# Altium schematic sheet MCU.SchDoc
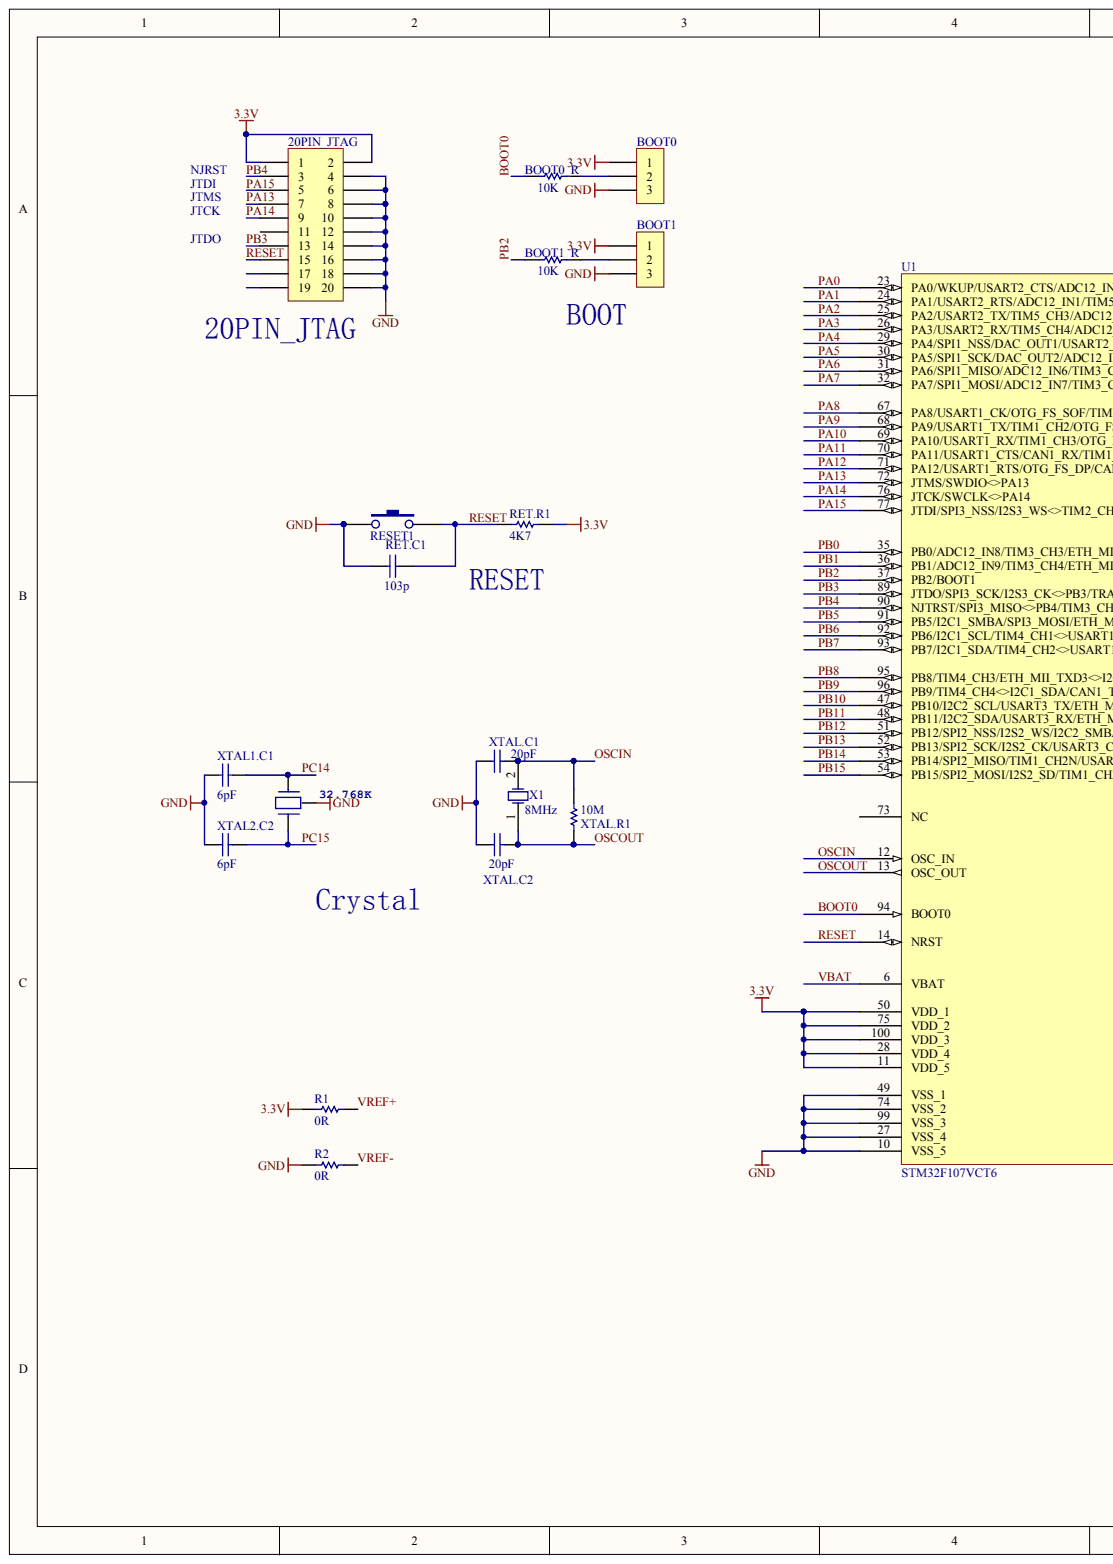
[diagram p0: MCU.SchDoc, left]
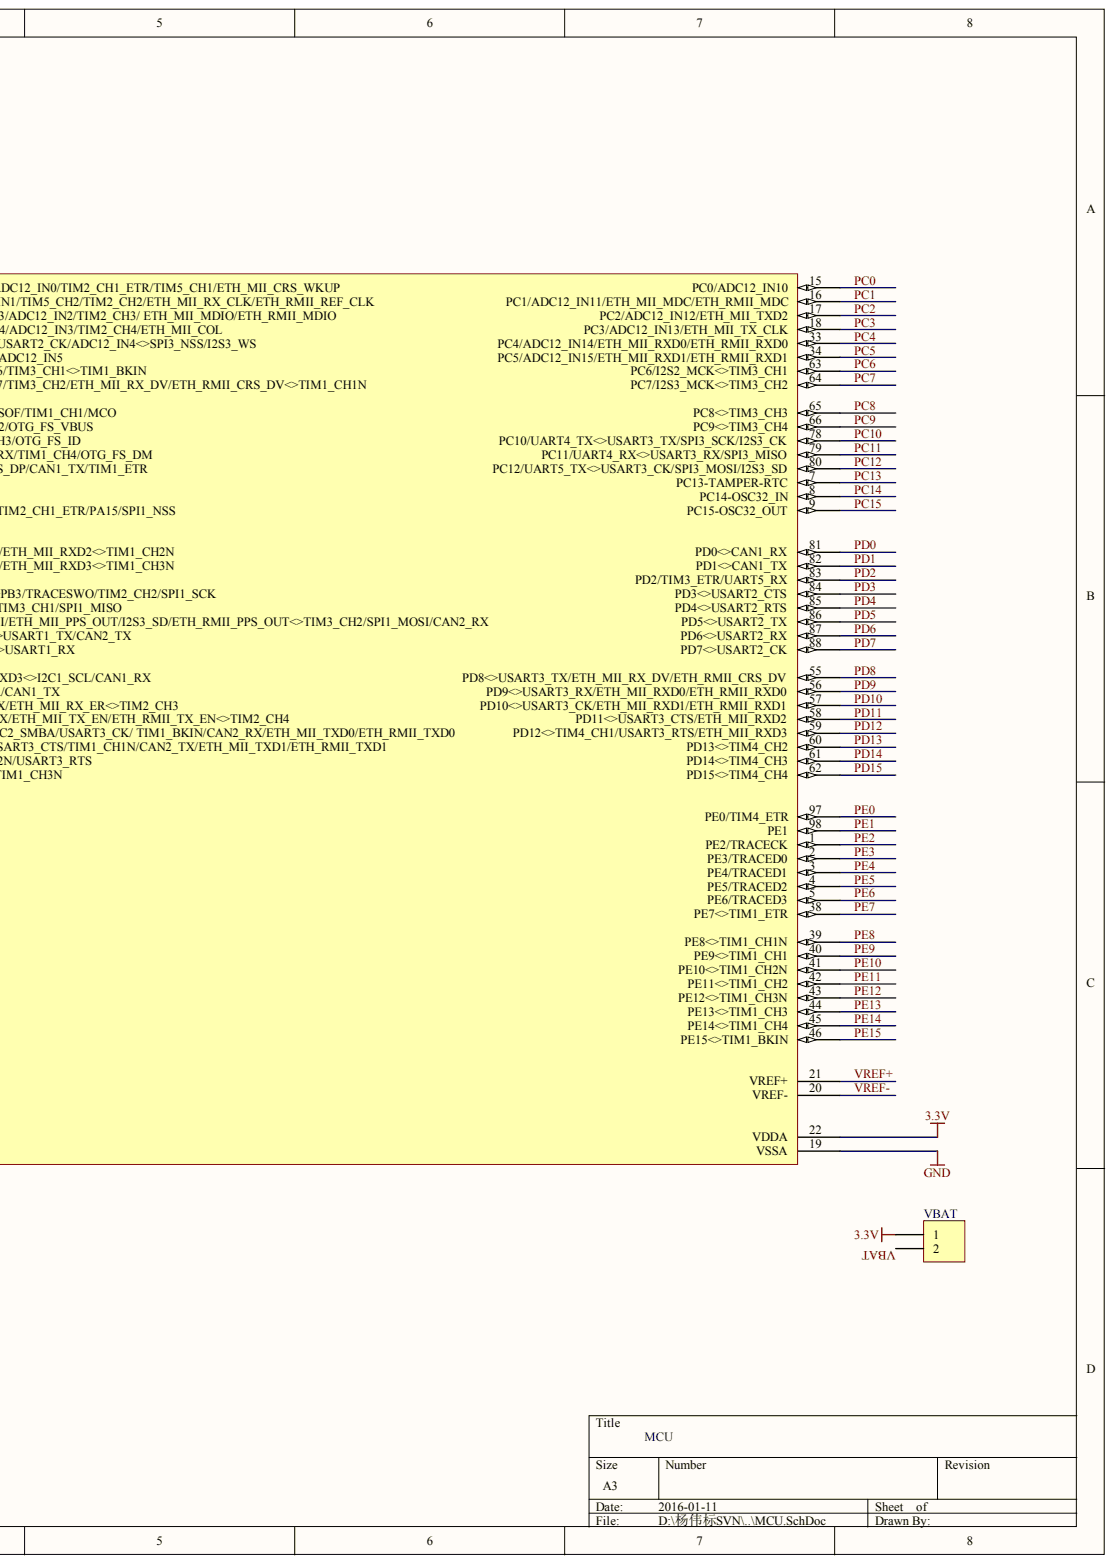
[diagram p1: MCU.SchDoc, right]

## Parts
20PIN_JTAG  20PIN_JTAG  [20PIN_JTAG]
BOOT0  (no value)  [SIP3]
BOOT0_R  10K  [0603]
BOOT1  (no value)  [SIP3]
BOOT1_R  10K  [0603]
R1  0R  [0603]
R2  0R  [0603]
RESET1  (no value)  [KEY]
RET.C1  103p  [0603]
RET.R1  4K7  [0603]
U1  STM32F107VCT6  [TQFP100]
VBAT  (no value)  [SIP2]
X1  8MHz  [CRYSTAL2]
X2  32.768K  [CRYSTAL3]
XTAL1.C1  6pF  [0603]
XTAL2.C2  6pF  [0603]
XTAL.C1  20pF  [0603]
XTAL.C2  20pF  [0603]
XTAL.R1  10M  [0603]

## Nets
3.3V: 20PIN_JTAG.1 20PIN_JTAG.2 BOOT0.1 BOOT1.1 R1.2 RET.R1.2 U1.11(VDD_5) U1.22(VDDA) U1.28(VDD_4) U1.50(VDD_1) U1.75(VDD_2) U1.100(VDD_3) VBAT.1
GND: 20PIN_JTAG.4 20PIN_JTAG.6 20PIN_JTAG.8 20PIN_JTAG.10 20PIN_JTAG.12 20PIN_JTAG.14 20PIN_JTAG.16 20PIN_JTAG.18 20PIN_JTAG.20 BOOT0.3 BOOT1.3 R2.2 RESET1.1 RET.C1.1 U1.10(VSS_5) U1.19(VSSA) U1.27(VSS_4) U1.49(VSS_1) U1.74(VSS_2) U1.99(VSS_3) X2.3 XTAL1.C1.1 XTAL2.C2.1 XTAL.C1.1 XTAL.C2.1
BOOT0: BOOT0_R.2 U1.94(BOOT0)
OSCIN: U1.12(OSC_IN) X1.2 XTAL.C1.2 XTAL.R1.2
OSCOUT: U1.13(OSC_OUT) X1.1 XTAL.C2.2 XTAL.R1.1
PA0: U1.23(PA0/WKUP/USART2_CTS/ADC12_IN0/TIM2_CH1_ETR/TIM5_CH1/ETH_MII_CRS_WKUP)
PA1: U1.24(PA1/USART2_RTS/ADC12_IN1/TIM5_CH2/TIM2_CH2/ETH_MII_RX_CLK/ETH_RMII_REF_CLK)
PA2: U1.25(PA2/USART2_TX/TIM5_CH3/ADC12_IN2/TIM2_CH3/_ETH_MII_MDIO/ETH_RMII_MDIO)
PA3: U1.26(PA3/USART2_RX/TIM5_CH4/ADC12_IN3/TIM2_CH4/ETH_MII_COL)
PA4: U1.29(PA4/SPI1_NSS/DAC_OUT1/USART2_CK/ADC12_IN4<>SPI3_NSS/I2S3_WS)
PA5: U1.30(PA5/SPI1_SCK/DAC_OUT2/ADC12_IN5)
PA6: U1.31(PA6/SPI1_MISO/ADC12_IN6/TIM3_CH1<>TIM1_BKIN)
PA7: U1.32(PA7/SPI1_MOSI/ADC12_IN7/TIM3_CH2/ETH_MII_RX_DV/ETH_RMII_CRS_DV<>TIM1_CH1N)
PA8: U1.67(PA8/USART1_CK/OTG_FS_SOF/TIM1_CH1/MCO)
PA9: U1.68(PA9/USART1_TX/TIM1_CH2/OTG_FS_VBUS)
PA10: U1.69(PA10/USART1_RX/TIM1_CH3/OTG_FS_ID)
PA11: U1.70(PA11/USART1_CTS/CAN1_RX/TIM1_CH4/OTG_FS_DM)
PA12: U1.71(PA12/USART1_RTS/OTG_FS_DP/CAN1_TX/TIM1_ETR)
PA13: 20PIN_JTAG.7 U1.72(JTMS/SWDIO<>PA13)
PA14: 20PIN_JTAG.9 U1.76(JTCK/SWCLK<>PA14)
PA15: 20PIN_JTAG.5 U1.77(JTDI/SPI3_NSS/I2S3_WS<>TIM2_CH1_ETR/PA15/SPI1_NSS)
PB0: U1.35(PB0/ADC12_IN8/TIM3_CH3/ETH_MII_RXD2<>TIM1_CH2N)
PB1: U1.36(PB1/ADC12_IN9/TIM3_CH4/ETH_MII_RXD3<>TIM1_CH3N)
PB2: BOOT1_R.2 U1.37(PB2/BOOT1)
PB3: 20PIN_JTAG.13 U1.89(JTDO/SPI3_SCK/I2S3_CK<>PB3/TRACESWO/TIM2_CH2/SPI1_SCK)
PB4: 20PIN_JTAG.3 U1.90(NJTRST/SPI3_MISO<>PB4/TIM3_CH1/SPI1_MISO)
PB5: U1.91(PB5/I2C1_SMBA/SPI3_MOSI/ETH_MII_PPS_OUT/I2S3_SD/ETH_RMII_PPS_OUT<>TIM3_CH2/SPI1_MOSI/CAN2_RX)
PB6: U1.92(PB6/I2C1_SCL/TIM4_CH1<>USART1_TX/CAN2_TX)
PB7: U1.93(PB7/I2C1_SDA/TIM4_CH2<>USART1_RX)
PB8: U1.95(PB8/TIM4_CH3/ETH_MII_TXD3<>I2C1_SCL/CAN1_RX)
PB9: U1.96(PB9/TIM4_CH4<>I2C1_SDA/CAN1_TX)
PB10: U1.47(PB10/I2C2_SCL/USART3_TX/ETH_MII_RX_ER<>TIM2_CH3)
PB11: U1.48(PB11/I2C2_SDA/USART3_RX/ETH_MII_TX_EN/ETH_RMII_TX_EN<>TIM2_CH4)
PB12: U1.51(PB12/SPI2_NSS/I2S2_WS/I2C2_SMBA/USART3_CK/_TIM1_BKIN/CAN2_RX/ETH_MII_TXD0/ETH_RMII_TXD0)
PB13: U1.52(PB13/SPI2_SCK/I2S2_CK/USART3_CTS/TIM1_CH1N/CAN2_TX/ETH_MII_TXD1/ETH_RMII_TXD1)
PB14: U1.53(PB14/SPI2_MISO/TIM1_CH2N/USART3_RTS)
PB15: U1.54(PB15/SPI2_MOSI/I2S2_SD/TIM1_CH3N)
PC0: U1.15(PC0/ADC12_IN10)
PC1: U1.16(PC1/ADC12_IN11/ETH_MII_MDC/ETH_RMII_MDC)
PC2: U1.17(PC2/ADC12_IN12/ETH_MII_TXD2)
PC3: U1.18(PC3/ADC12_IN13/ETH_MII_TX_CLK)
PC4: U1.33(PC4/ADC12_IN14/ETH_MII_RXD0/ETH_RMII_RXD0)
PC5: U1.34(PC5/ADC12_IN15/ETH_MII_RXD1/ETH_RMII_RXD1)
PC6: U1.63(PC6/I2S2_MCK<>TIM3_CH1)
PC7: U1.64(PC7/I2S3_MCK<>TIM3_CH2)
PC8: U1.65(PC8<>TIM3_CH3)
PC9: U1.66(PC9<>TIM3_CH4)
PC10: U1.78(PC10/UART4_TX<>USART3_TX/SPI3_SCK/I2S3_CK)
PC11: U1.79(PC11/UART4_RX<>USART3_RX/SPI3_MISO)
PC12: U1.80(PC12/UART5_TX<>USART3_CK/SPI3_MOSI/I2S3_SD)
PC13: U1.7(PC13-TAMPER-RTC)
PC14: U1.8(PC14-OSC32_IN) X2.2 XTAL1.C1.2
PC15: U1.9(PC15-OSC32_OUT) X2.1 XTAL2.C2.2
PD0: U1.81(PD0<>CAN1_RX)
PD1: U1.82(PD1<>CAN1_TX)
PD2: U1.83(PD2/TIM3_ETR/UART5_RX)
PD3: U1.84(PD3<>USART2_CTS)
PD4: U1.85(PD4<>USART2_RTS)
PD5: U1.86(PD5<>USART2_TX)
PD6: U1.87(PD6<>USART2_RX)
PD7: U1.88(PD7<>USART2_CK)
PD8: U1.55(PD8<>USART3_TX/ETH_MII_RX_DV/ETH_RMII_CRS_DV)
PD9: U1.56(PD9<>USART3_RX/ETH_MII_RXD0/ETH_RMII_RXD0)
PD10: U1.57(PD10<>USART3_CK/ETH_MII_RXD1/ETH_RMII_RXD1)
PD11: U1.58(PD11<>USART3_CTS/ETH_MII_RXD2)
PD12: U1.59(PD12<>TIM4_CH1/USART3_RTS/ETH_MII_RXD3)
PD13: U1.60(PD13<>TIM4_CH2)
PD14: U1.61(PD14<>TIM4_CH3)
PD15: U1.62(PD15<>TIM4_CH4)
PE0: U1.97(PE0/TIM4_ETR)
PE1: U1.98(PE1)
PE2: U1.1(PE2/TRACECK)
PE3: U1.2(PE3/TRACED0)
PE4: U1.3(PE4/TRACED1)
PE5: U1.4(PE5/TRACED2)
PE6: U1.5(PE6/TRACED3)
PE7: U1.38(PE7<>TIM1_ETR)
PE8: U1.39(PE8<>TIM1_CH1N)
PE9: U1.40(PE9<>TIM1_CH1)
PE10: U1.41(PE10<>TIM1_CH2N)
PE11: U1.42(PE11<>TIM1_CH2)
PE12: U1.43(PE12<>TIM1_CH3N)
PE13: U1.44(PE13<>TIM1_CH3)
PE14: U1.45(PE14<>TIM1_CH4)
PE15: U1.46(PE15<>TIM1_BKIN)
RESET: 20PIN_JTAG.15 RESET1.2 RET.C1.2 RET.R1.1 U1.14(NRST)
VBAT: U1.6(VBAT) VBAT.2
VREF+: R1.1 U1.21(VREF+)
VREF-: R2.1 U1.20(VREF-)
NetBOOT0_2: BOOT0.2 BOOT0_R.1
NetBOOT1_2: BOOT1.2 BOOT1_R.1

Not connected: 20PIN_JTAG 11 17 19; U1 73(NC)

## Library data of the parts
BOOT0 BOOT1 = Header 3: Header, 3-Pin; Component Kind: Standard; Library Name: zz.SchLib
BOOT0_R BOOT1_R RET.R1 = R_0805: Resistor; Component Kind: Standard; Library Name: zz.SchLib
  BOOT0_R BOOT1_R: Value: 10K
  RET.R1: Value: 4K7
R1 R2 XTAL.R1 = R_0805: Resistor; Value: 1M; Component Kind: Standard; Library Name: zz.SchLib
RESET1 = KEY: Component Kind: Standard; Library Name: zz.SchLib
RET.C1 XTAL.C1 XTAL.C2 = C_0805: Capacitor; Component Kind: Standard; Library Name: zz.SchLib
  RET.C1: Value: 103p
  XTAL.C1 XTAL.C2: Value: 20pF
U1 = STM32F107V: Component Kind: Standard; Library Name: Schlib1.SchLib
VBAT = Header 2: Header, 2-Pin; Component Kind: Standard; LatestRevisionDate: 17-Jul-2002; LatestRevisionNote: Re-released for DXP Platform.; Library Name: zz.SchLib; Publisher: Altium Limited
X1 = XTAL0: Crystal Oscillator; Component Kind: Standard; LatestRevisionDate: 17-Jul-2002; LatestRevisionNote: Re-released for DXP Platform.; Library Name: zz.SchLib; PackageReference: R38; Published: 8-Jun-2000; Publisher: Altium Limited
X2 = XTAL2: CRYSTAL; Component Kind: Standard; Library Name: zz.SchLib
XTAL1.C1 XTAL2.C2 = C_0805: Capacitor; Value: 20pF; Component Kind: Standard; Library Name: zz.SchLib
20PIN_JTAG = 20PIN_JTAG: Component Kind: Standard; Library Name: zz.SchLib
